# Altium schematic sheet Microbit built-in.SchDoc
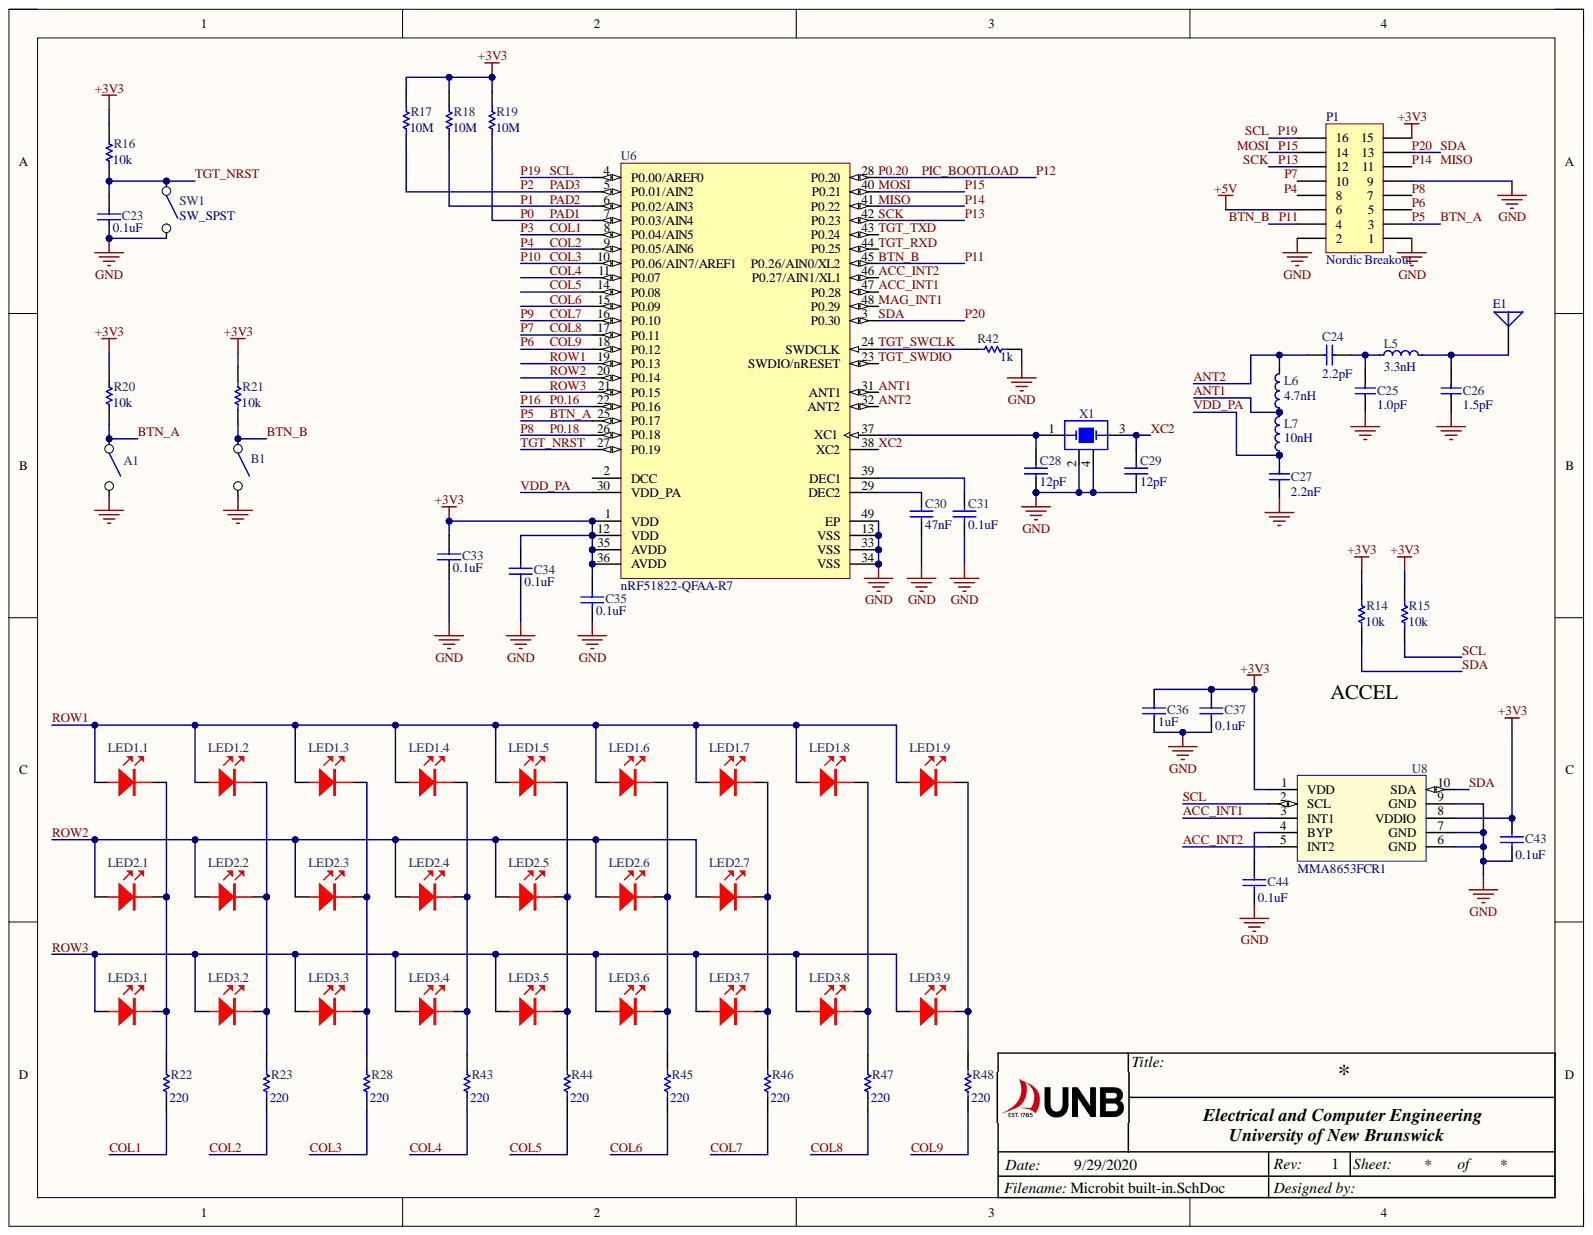
[diagram p0: Microbit built-in.SchDoc]

## Parts
A1  SW_SPST_6MM  [SW_FSM4JSMA]  (value not drawn)
B1  SW_SPST_6MM  [SW_FSM4JSMA]  (value not drawn)
C23  0.1uF  [0603 Cap]
C24  2.2pF  [CAPC1005X04L]
C25  1.0pF  [CAPC1005X04L]
C26  1.5pF  [CAPC1005X04L]
C27  2.2nF  [CAPC1005X04L]
C28  12pF  [CAPC1005X04L]
C29  12pF  [CAPC1005X04L]
C30  47nF  [CAPC1005X04L]
C31  0.1uF  [CAPC1005X04L]
C33  0.1uF  [CAPC1005X04L]
C34  0.1uF  [CAPC1005X04L]
C35  0.1uF  [CAPC1005X04L]
C36  1uF  [0603 Cap]
C37  0.1uF  [0603 Cap]
C43  0.1uF  [0603 Cap]
C44  0.1uF  [0603 Cap]
E1  ANT-2.45-CHP-T, 2.4GHz, 802.11, Bluetooth, SMD  [ANT-2.45-CHP]  (value not drawn)
L5  3.3nH  [INDC1005X04L]
L6  4.7nH  [INDC1005X04L]
L7  10nH  [INDC1005X04L]
LED1.1  LED_0603_RED  [LED_0603_RED]  (value not drawn)
LED1.2  LED_0603_RED  [LED_0603_RED]  (value not drawn)
LED1.3  LED_0603_RED  [LED_0603_RED]  (value not drawn)
LED1.4  LED_0603_RED  [LED_0603_RED]  (value not drawn)
LED1.5  LED_0603_RED  [LED_0603_RED]  (value not drawn)
LED1.6  LED_0603_RED  [LED_0603_RED]  (value not drawn)
LED1.7  LED_0603_RED  [LED_0603_RED]  (value not drawn)
LED1.8  LED_0603_RED  [LED_0603_RED]  (value not drawn)
LED1.9  LED_0603_RED  [LED_0603_RED]  (value not drawn)
LED2.1  LED_0603_RED  [LED_0603_RED]  (value not drawn)
LED2.2  LED_0603_RED  [LED_0603_RED]  (value not drawn)
LED2.3  LED_0603_RED  [LED_0603_RED]  (value not drawn)
LED2.4  LED_0603_RED  [LED_0603_RED]  (value not drawn)
LED2.5  LED_0603_RED  [LED_0603_RED]  (value not drawn)
LED2.6  LED_0603_RED  [LED_0603_RED]  (value not drawn)
LED2.7  LED_0603_RED  [LED_0603_RED]  (value not drawn)
LED3.1  LED_0603_RED  [LED_0603_RED]  (value not drawn)
LED3.2  LED_0603_RED  [LED_0603_RED]  (value not drawn)
LED3.3  LED_0603_RED  [LED_0603_RED]  (value not drawn)
LED3.4  LED_0603_RED  [LED_0603_RED]  (value not drawn)
LED3.5  LED_0603_RED  [LED_0603_RED]  (value not drawn)
LED3.6  LED_0603_RED  [LED_0603_RED]  (value not drawn)
LED3.7  LED_0603_RED  [LED_0603_RED]  (value not drawn)
LED3.8  LED_0603_RED  [LED_0603_RED]  (value not drawn)
LED3.9  LED_0603_RED  [LED_0603_RED]  (value not drawn)
P1  Nordic Breakout  [HDR2X8]
R14  10k  [0603 Resistor]
R15  10k  [0603 Resistor]
R16  10k  [0603 Resistor]
R17  10M  [0603 Resistor]
R18  10M  [0603 Resistor]
R19  10M  [0603 Resistor]
R20  10k  [0603 Resistor]
R21  10k  [0603 Resistor]
R22  220  [0603 Resistor]
R23  220  [0603 Resistor]
R28  220  [0603 Resistor]
R42  1k  [RESC1005X04L]
R43  220  [0603 Resistor]
R44  220  [0603 Resistor]
R45  220  [0603 Resistor]
R46  220  [0603 Resistor]
R47  220  [0603 Resistor]
R48  220  [0603 Resistor]
SW1  SW_SPST  [PTS636 SK25F SMTR LFS]
U6  nRF51822-QFAA-R7  [NRSC-QF-48_L]
U8  MMA8653FCR1  [IC_QFN40P200X200X85-10M]
X1  XTAL 16MHZ 2.5 x 2 mm  [BT-XTAL_2520]  (value not drawn)

## Nets
+3V3: C33.1 C34.1 C35.1 C36.2 C37.2 C43.2 P1.15 R14.1 R15.1 R16.1 R17.1 R18.1 R19.1 R20.1 R21.1 U6.1(VDD) U6.12(VDD) U6.35(AVDD) U6.36(AVDD) U8.1(VDD) U8.8(VDDIO)
+5V: P1.6
GND: A1.2 B1.2 C23.1 C25.2 C26.2 C27.2 C28.2 C29.2 C30.2 C31.2 C33.2 C34.2 C35.2 C36.1 C37.1 C43.1 C44.1 P1.1 P1.2 P1.9 R42.1 SW1.2 U6.13(VSS) U6.33(VSS) U6.34(VSS) U6.49(EP) U8.6(GND) U8.7(GND) U8.9(GND) X1.2 X1.4
ACC_INT1: U6.47(P0.28) U8.3(INT1)
ACC_INT2: U6.46(P0.27/AIN1/XL1) U8.5(INT2)
ANT1: L6.1 L7.2 U6.31(ANT1)
ANT2: C24.1 L6.2 U6.32(ANT2)
BTN_A: A1.1 P1.3 R20.2 U6.25(P0.17)
BTN_B: B1.1 P1.4 R21.2 U6.45(P0.26/AIN0/XL2)
COL1: R22.2 U6.8(P0.04/AIN5)
COL2: P1.8 R23.2 U6.9(P0.05/AIN6)
COL3: R28.2 U6.10(P0.06/AIN7/AREF1)
COL4: R43.2 U6.11(P0.07)
COL5: R44.2 U6.14(P0.08)
COL6: R45.2 U6.15(P0.09)
COL7: R46.2 U6.16(P0.10)
COL8: P1.10 R47.2 U6.17(P0.11)
COL9: P1.5 R48.2 U6.18(P0.12)
MAG_INT1: U6.48(P0.29)
MISO: P1.11 U6.41(P0.22)
MOSI: P1.14 U6.40(P0.21)
P0: R19.2 U6.7(P0.03/AIN4)
P0.16: U6.22(P0.16)
P0.18: P1.7 U6.26(P0.18)
P0.20: U6.28(P0.20)
P1: R18.2 U6.6(P0.02/AIN3)
P2: R17.2 U6.5(P0.01/AIN2)
P13: P1.12 U6.42(P0.23)
P19: P1.16 R15.2 U6.4(P0.00/AREF0) U8.2(SCL)
P20: P1.13 R14.2 U6.3(P0.30) U8.10(SDA)
ROW1: LED1.1.A LED1.2.A LED1.3.A LED1.4.A LED1.5.A LED1.6.A LED1.7.A LED1.8.A LED1.9.A U6.19(P0.13)
ROW2: LED2.1.A LED2.2.A LED2.3.A LED2.4.A LED2.5.A LED2.6.A LED2.7.A U6.20(P0.14)
ROW3: LED3.1.A LED3.2.A LED3.3.A LED3.4.A LED3.5.A LED3.6.A LED3.7.A LED3.8.A LED3.9.A U6.21(P0.15)
TGT_NRST: C23.2 R16.2 SW1.1 U6.27(P0.19)
TGT_RXD: U6.44(P0.25)
TGT_SWCLK: R42.2 U6.24(SWDCLK)
TGT_SWDIO: U6.23(SWDIO/nRESET)
TGT_TXD: U6.43(P0.24)
VDD_PA: C27.1 L7.1 U6.30(VDD_PA)
XC2: C29.1 U6.38(XC2) X1.3
NetC24_2: C24.2 C25.1 L5.1
NetC26_1: C26.1 E1.1 L5.2
NetC28_1: C28.1 U6.37(XC1) X1.1
NetC30_1: C30.1 U6.29(DEC2)
NetC31_1: C31.1 U6.39(DEC1)
NetC44_2: C44.2 U8.4(BYP)
NetLED1.1_K: LED1.1.K LED2.1.K LED3.1.K R22.1
NetLED1.2_K: LED1.2.K LED2.2.K LED3.2.K R23.1
NetLED1.3_K: LED1.3.K LED2.3.K LED3.3.K R28.1
NetLED1.4_K: LED1.4.K LED2.4.K LED3.4.K R43.1
NetLED1.5_K: LED1.5.K LED2.5.K LED3.5.K R44.1
NetLED1.6_K: LED1.6.K LED2.6.K LED3.6.K R45.1
NetLED1.7_K: LED1.7.K LED2.7.K LED3.7.K R46.1
NetLED1.8_K: LED1.8.K LED3.8.K R47.1
NetLED1.9_K: LED1.9.K LED3.9.K R48.1

Not connected: U6 2(DCC)

## Library data of the parts
A1 B1 = SW_SPST_6MM: Switch Tactile OFF (ON) SPST Round Button Gull Wing 0.05A 12VDC 1.57N SMD Loose Piece; Manufacturer: TE Connectivity; Manufacturer Part Number: FSM4JSMA; Actuator Length: 1.4mm; Contact Current Rating: 50mA; Contact Resistance: 100mR; Electrical Life: 100000Cycles; Max Voltage Rating (DC): 12V; Case/Package: SMD/SMT; Category: Pushbutton Switches; Color: Black; Component Kind: Standard; ComponentLink1Description: https://datasheet.ciiva.com/14635/0900766b80912216-14635826.pdf?src-supplier=Allied+Electronics; ComponentLink1URL: https://datasheet.ciiva.com/14635/0900766b80912216-14635826.pdf?src-supplier=Allied+Electronics; Contact Material: Copper Alloy,Silver; Contact Plating: Silver; Depth: 10mm; ELV: Compliant; Insulation Resistance: 100MR; Lead Free: Lead Free; Length: 6mm; Max Current Rating: 50mA; Max Operating Temperature: 85°C; Min Operating Temperature: -20°C; Mount: Surface Mount; Operating Force: 1.57N; Orientation: Straight; Packaging: Bulk; Radiation Hardening: No; REACH SVHC: No SVHC; RoHS: Yes; Stock 1: 18617; Supplier 1: Digi-Key; Supplier Part Number 1: 450-1129-ND; Termination: Gull Wing; Throw Configuration: SPST; Voltage Rating (DC): 12V; Library Name: Arbury_SCH.SchLib
C23 C37 C43 C44 = Cap Semi: Cap Ceramic 0.1uF 50VDC X7R 10% SMD 0603 Paper T/R; Manufacturer: Samsung; Manufacturer Part Number: CL10B104KB8NNNC; Capacitance: 100nF; Voltage: 50V; Case Code (Imperial): 0603; Case Code (Metric): 1608; Case/Package: 0603; Category: Ceramic Capacitors; Component Kind: Standard; ComponentLink1Description: http://www.avx.com/docs/Catalogs/cx7r.pdf; ComponentLink1URL: http://www.avx.com/docs/Catalogs/cx7r.pdf; ComponentLink2Description: https://datasheet.ciiva.com/25803/cl10b104kb8nnnc-25803393.pdf?src-supplier=Digi-Key; ComponentLink2URL: https://datasheet.ciiva.com/25803/cl10b104kb8nnnc-25803393.pdf?src-supplier=Digi-Key; ComponentLink3Description: https://datasheet.ciiva.com/26838/getdatasheetpartid-89097-26838411.pdf?src-supplier=Verical; ComponentLink3URL: https://datasheet.ciiva.com/26838/getdatasheetpartid-89097-26838411.pdf?src-supplier=Verical; ComponentLink4Description: https://datasheet.ciiva.com/29198/456651-da-01-en-vs-kondensator-0605-29198839.pdf?src-supplier=Conrad; ComponentLink4URL: https://datasheet.ciiva.com/29198/456651-da-01-en-vs-kondensator-0605-29198839.pdf?src-supplier=Conrad; Contact Plating: Tin; Depth: 0.8mm; Dielectric: X7R; Height: 0.8mm; Lead Free: Lead Free; Length: 1.6mm; Library Name: CAN_Interface_Library.SCHLIB; Material: Ceramic; Max Operating Temperature: 125°C; Min Operating Temperature: -55°C; Mount: Surface Mount; Package Quantity: 1; Packaging: Tape and Reel; Radiation Hardening: No; RoHS: Yes; Signal Integrity: Cap Semi; Simulation: CAP; Supplier 1: Digi-Key; Thickness: 0.035inch; Tolerance: 10%; Value: 0.1uF; Voltage Rating: 50V; Voltage Rating (DC): 50V; Width: 0.031inch
  C23: Stock 1: 17879275; Supplier Part Number 1: 1276-1000-6-ND
  C37 C43 C44: Stock 1: 17674061; Supplier Part Number 1: 1276-1000-1-ND
C24 = C0402-2.2p: Murata 2.2pF Multilayer Ceramic Capacitor (MLCC) 50 V dc 0.25pF C0G dielectric GJM max op. temp. +125C; Manufacturer Part Number: GJM1555C1H2R2CB01D; Database Table Name: Capacitors$; Voltage: 50V; Capacitance: 2.2pF; Case Code (Imperial): 0402; Case Code (Metric): 1005; Case/Package: 0402; Category: Ceramic Capacitors; Component Kind: Standard; ComponentLink1Description: https://datasheet.ciiva.com/17453/product-17453491.pdf?src-supplier=Avnet+Europe; ComponentLink1URL: https://datasheet.ciiva.com/17453/product-17453491.pdf?src-supplier=Avnet+Europe; ComponentLink2Description: https://datasheet.ciiva.com/18183/getdatasheetpartid-370505-18183831.pdf?src-supplier=Verical; ComponentLink2URL: https://datasheet.ciiva.com/18183/getdatasheetpartid-370505-18183831.pdf?src-supplier=Verical; ComponentLink3Description: https://datasheet.ciiva.com/132/partnumbering-e-01-132409.pdf?src-supplier=Digi-Key; ComponentLink3URL: https://datasheet.ciiva.com/132/partnumbering-e-01-132409.pdf?src-supplier=Digi-Key; ComponentLink4Description: https://datasheet.ciiva.com/132/partnumbering-e-01-132410.pdf?src-supplier=Digi-Key; ComponentLink4URL: https://datasheet.ciiva.com/132/partnumbering-e-01-132410.pdf?src-supplier=Digi-Key; ComponentLink5Description: https://datasheet.ciiva.com/132/partnumbering-e-01-132411.pdf?src-supplier=Digi-Key; ComponentLink5URL: https://datasheet.ciiva.com/132/partnumbering-e-01-132411.pdf?src-supplier=Digi-Key; ComponentLink6Description: https://datasheet.ciiva.com/16440/l0005e-16440478.pdf?src-supplier=Future+Electronics; ComponentLink6URL: https://datasheet.ciiva.com/16440/l0005e-16440478.pdf?src-supplier=Future+Electronics; Depth: 0.5mm; Dielectric: C0G; Height: 0.5mm; Lead Free: Lead Free; Length: 1mm; Library Name: Nordic Passive DB.DbLib; Manufacturer: Murata; Max Operating Temperature: 125°C; Min Operating Temperature: -55°C; Mount: Surface Mount; Package Quantity: 1; Packaging: Tape and Reel; Radiation Hardening: No; RoHS: Yes; Stock 1: 101982; Supplier 1: Digi-Key; Supplier Part Number 1: 490-3091-1-ND; Termination: SMD/SMT; Thickness: 0.022inch; Value: 2.2pF; Voltage Rating: 50V; Voltage Rating (DC): 50V; Width: 0.02inch
C25 = C0402-1.0p: CAP CER 1PF 50V C0G/NP0 0402; Manufacturer: Murata; Manufacturer Part Number: GJM1555C1H1R0CB01D; Database Table Name: Capacitors$; Dielectric: C0G; Temperature Coefficient: 30ppm/°C; Capacitance: 1pF; Case Code (Imperial): 0402; Case Code (Metric): 1005; Case/Package: 0402; Category: Ceramic Capacitors; Component Kind: Standard; ComponentLink1Description: https://datasheet.ciiva.com/133/product-133104.pdf?src-supplier=Digi-Key; ComponentLink1URL: https://datasheet.ciiva.com/133/product-133104.pdf?src-supplier=Digi-Key; ComponentLink2Description: https://datasheet.ciiva.com/8030/1700189-8030101.pdf?src-supplier=Element14; ComponentLink2URL: https://datasheet.ciiva.com/8030/1700189-8030101.pdf?src-supplier=Element14; ComponentLink3Description: https://datasheet.ciiva.com/18183/getdatasheetpartid-254995-18183819.pdf?src-supplier=Verical; ComponentLink3URL: https://datasheet.ciiva.com/18183/getdatasheetpartid-254995-18183819.pdf?src-supplier=Verical; ComponentLink4Description: https://datasheet.ciiva.com/11789/0900766b80ef921d-11789100.pdf?src-supplier=RSComponents; ComponentLink4URL: https://datasheet.ciiva.com/11789/0900766b80ef921d-11789100.pdf?src-supplier=RSComponents; ComponentLink5Description: https://datasheet.ciiva.com/133/partnumbering-e-01-133102.pdf?src-supplier=Digi-Key; ComponentLink5URL: https://datasheet.ciiva.com/133/partnumbering-e-01-133102.pdf?src-supplier=Digi-Key; ComponentLink6Description: https://datasheet.ciiva.com/133/partnumbering-e-01-133103.pdf?src-supplier=Digi-Key; ComponentLink6URL: https://datasheet.ciiva.com/133/partnumbering-e-01-133103.pdf?src-supplier=Digi-Key; Depth: 0.5mm; Height: 0.5mm; Lead Free: Lead Free; Lead Pitch: 0.3mm; Length: 1mm; Library Name: Nordic Passive DB.DbLib; Max Operating Temperature: 125°C; Min Operating Temperature: -55°C; Mount: Surface Mount; Number of Pins: 2; Package Quantity: 1; Packaging: Tape and Reel; Radiation Hardening: No; REACH SVHC: No SVHC; RoHS: Yes; Stock 1: 37797; Supplier 1: Digi-Key; Supplier Part Number 1: 490-3083-1-ND; Termination: SMD/SMT; Thickness: 0.022inch; Value: 1.0pF; Voltage: 50V; Voltage Rating: 50V; Voltage Rating (DC): 50V; Width: 0.5mm
C26 = C0402-1.5p: CAP CER 1.5PF 50V C0G/NP0 0402; Manufacturer: Murata; Manufacturer Part Number: GJM1555C1H1R5CB01D; Database Table Name: Capacitors$; Dielectric: C0G; Capacitance: 1.5pF; Case Code (Imperial): 0402; Case Code (Metric): 1005; Case/Package: 0402; Category: Ceramic Capacitors; Component Kind: Standard; ComponentLink1Description: https://datasheet.ciiva.com/133/product-133136.pdf?src-supplier=Digi-Key; ComponentLink1URL: https://datasheet.ciiva.com/133/product-133136.pdf?src-supplier=Digi-Key; ComponentLink2Description: https://datasheet.ciiva.com/18183/getdatasheetpartid-306679-18183822.pdf?src-supplier=Verical; ComponentLink2URL: https://datasheet.ciiva.com/18183/getdatasheetpartid-306679-18183822.pdf?src-supplier=Verical; ComponentLink3Description: https://datasheet.ciiva.com/133/partnumbering-e-01-133137.pdf?src-supplier=Digi-Key; ComponentLink3URL: https://datasheet.ciiva.com/133/partnumbering-e-01-133137.pdf?src-supplier=Digi-Key; ComponentLink4Description: https://datasheet.ciiva.com/17453/product-17453482.pdf?src-supplier=Avnet+Europe; ComponentLink4URL: https://datasheet.ciiva.com/17453/product-17453482.pdf?src-supplier=Avnet+Europe; ComponentLink5Description: https://datasheet.ciiva.com/133/partnumbering-e-01-133135.pdf?src-supplier=Digi-Key; ComponentLink5URL: https://datasheet.ciiva.com/133/partnumbering-e-01-133135.pdf?src-supplier=Digi-Key; Depth: 0.5mm; Height: 0.5mm; Lead Free: Lead Free; Length: 1mm; Library Name: Nordic Passive DB.DbLib; Max Operating Temperature: 125°C; Min Operating Temperature: -55°C; Mount: Surface Mount; Package Quantity: 1; Packaging: Tape and Reel; Radiation Hardening: No; RoHS: Yes; Stock 1: 113468; Supplier 1: Digi-Key; Supplier Part Number 1: 490-3087-1-ND; Termination: SMD/SMT; Thickness: 0.022inch; Value: 1.5pF; Voltage: 50V; Voltage Rating: 50V; Voltage Rating (DC): 50V; Width: 0.02inch
C27 = C0402-2n2: MURATA - GRM155R71H222KA01D - Keramikvielschichtkondensator, SMD, 2200 pF, 50 V, 0402 [Metrisch 1005], ± 10%, X7R, GRM Series; Database Table Name: Capacitors$; Material: Ceramic; Voltage: 50V; Capacitance: 2.2nF; Case Code (Imperial): 0402; Case Code (Metric): 1005; Case/Package: 0402; Category: Ceramic Capacitors; Component Kind: Standard; ComponentLink1Description: https://datasheet.ciiva.com/11786/0900766b80ef921d-11786696.pdf?src-supplier=RSComponents; ComponentLink1URL: https://datasheet.ciiva.com/11786/0900766b80ef921d-11786696.pdf?src-supplier=RSComponents; ComponentLink2Description: https://datasheet.ciiva.com/18184/getdatasheetpartid-127769-18184735.pdf?src-supplier=Verical; ComponentLink2URL: https://datasheet.ciiva.com/18184/getdatasheetpartid-127769-18184735.pdf?src-supplier=Verical; ComponentLink3Description: https://datasheet.ciiva.com/34302/1856468-34302855.pdf?src-supplier=Jameco; ComponentLink3URL: https://datasheet.ciiva.com/34302/1856468-34302855.pdf?src-supplier=Jameco; ComponentLink4Description: https://datasheet.ciiva.com/29199/454279-da-01-en-vs-kondensator-0402-29199761.pdf?src-supplier=Conrad; ComponentLink4URL: https://datasheet.ciiva.com/29199/454279-da-01-en-vs-kondensator-0402-29199761.pdf?src-supplier=Conrad; ComponentLink5Description: https://datasheet.ciiva.com/22698/608985-22698423.pdf?src-supplier=Element14; ComponentLink5URL: https://datasheet.ciiva.com/22698/608985-22698423.pdf?src-supplier=Element14; ComponentLink6Description: https://datasheet.ciiva.com/129/partnumbering-e-01-129864.pdf?src-supplier=Digi-Key; ComponentLink6URL: https://datasheet.ciiva.com/129/partnumbering-e-01-129864.pdf?src-supplier=Digi-Key; ComponentLink7Description: https://datasheet.ciiva.com/129/partnumbering-e-01-129866.pdf?src-supplier=Digi-Key; ComponentLink7URL: https://datasheet.ciiva.com/129/partnumbering-e-01-129866.pdf?src-supplier=Digi-Key; ComponentLink8Description: https://datasheet.ciiva.com/14116/grmseries-highdielectrictype-eng-14116908.pdf?src-supplier=Distrelec; ComponentLink8URL: https://datasheet.ciiva.com/14116/grmseries-highdielectrictype-eng-14116908.pdf?src-supplier=Distrelec; ComponentLink9Description: https://datasheet.ciiva.com/129/partnumbering-e-01-129865.pdf?src-supplier=Digi-Key; ComponentLink9URL: https://datasheet.ciiva.com/129/partnumbering-e-01-129865.pdf?src-supplier=Digi-Key; ComponentLink10Description: https://datasheet.ciiva.com/7937/608985-7937628.pdf?src-supplier=Element14; ComponentLink10URL: https://datasheet.ciiva.com/7937/608985-7937628.pdf?src-supplier=Element14; ComponentLink11Description: https://datasheet.ciiva.com/14116/grmseries-highdielectrictype-eng-14116847.pdf?src-supplier=Distrelec; ComponentLink11URL: https://datasheet.ciiva.com/14116/grmseries-highdielectrictype-eng-14116847.pdf?src-supplier=Distrelec; Depth: 0.5mm; Dielectric: X7R; Height: 0.5mm; Lead Free: Lead Free; Length: 1mm; Library Name: Nordic Passive DB.DbLib; Manufacturer: Murata; Manufacturer Part Number: GRM155R71H222KA01D; Max Operating Temperature: 125°C; Min Operating Temperature: -55°C; Mount: Surface Mount; Number of Pins: 2; Package Quantity: 1; Packaging: Tape and Reel; Radiation Hardening: No; REACH SVHC: No SVHC; RoHS: Yes; Stock 1: 1354506; Supplier 1: Digi-Key; Supplier Part Number 1: 490-1305-1-ND; Termination: SMD/SMT; Thickness: 0.022inch; Tolerance: 10%; Value: 2.2nF; Voltage Rating: 50V; Voltage Rating (DC): 50V; Weight: 2.3E-05oz; Width: 0.5mm
C28 C29 = Cap Semi: CAP CER 12PF 50V C0G/NPO 0402; Manufacturer: Yageo; Manufacturer Part Number: CC0402JRNPO9BN120; Dielectric: C0G; Tolerance: 5%; Capacitance: 12pF; Case Code (Imperial): 0402; Case Code (Metric): 1005; Case/Package: 0402; Category: Ceramic Capacitors; Component Kind: Standard; ComponentLink1Description: http://www.avx.com/docs/Catalogs/cx7r.pdf; ComponentLink1URL: http://www.avx.com/docs/Catalogs/cx7r.pdf; ComponentLink2Description: https://datasheet.ciiva.com/161/mlccorderingcode-161488.pdf?src-supplier=Digi-Key; ComponentLink2URL: https://datasheet.ciiva.com/161/mlccorderingcode-161488.pdf?src-supplier=Digi-Key; ComponentLink3Description: https://datasheet.ciiva.com/5425/upy-gp-np0-16v-to-50v-8-5425431.pdf?src-supplier=Mouser; ComponentLink3URL: https://datasheet.ciiva.com/5425/upy-gp-np0-16v-to-50v-8-5425431.pdf?src-supplier=Mouser; ComponentLink4Description: https://datasheet.ciiva.com/11587/upy-gp-np0-16v-to-50v-8-11587083.pdf?src-supplier=Avnet; ComponentLink4URL: https://datasheet.ciiva.com/11587/upy-gp-np0-16v-to-50v-8-11587083.pdf?src-supplier=Avnet; ComponentLink5Description: https://datasheet.ciiva.com/17434/upy-gp-np0-16v-to-50v-8-17434331.pdf?src-supplier=Avnet+Europe; ComponentLink5URL: https://datasheet.ciiva.com/17434/upy-gp-np0-16v-to-50v-8-17434331.pdf?src-supplier=Avnet+Europe; ComponentLink6Description: https://datasheet.ciiva.com/161/mlccorderingcode-161486.pdf?src-supplier=Digi-Key; ComponentLink6URL: https://datasheet.ciiva.com/161/mlccorderingcode-161486.pdf?src-supplier=Digi-Key; ComponentLink7Description: https://datasheet.ciiva.com/28450/1443161-28450222.pdf?src-supplier=Element14; ComponentLink7URL: https://datasheet.ciiva.com/28450/1443161-28450222.pdf?src-supplier=Element14; ComponentLink8Description: https://datasheet.ciiva.com/161/mlccorderingcode-161487.pdf?src-supplier=Digi-Key; ComponentLink8URL: https://datasheet.ciiva.com/161/mlccorderingcode-161487.pdf?src-supplier=Digi-Key; Composition: Ceramic; Depth: 0.5mm; Height: 0.5mm; Lead Free: Lead Free; Length: 1mm; Library Name: CAN_Interface_Library.SCHLIB; Max Operating Temperature: 125°C; Min Operating Temperature: -55°C; Mount: Surface Mount; Number of Pins: 2; Package Quantity: 1; Packaging: Tape and Reel; Radiation Hardening: No; REACH SVHC: No SVHC; RoHS: Yes; Signal Integrity: Cap Semi; Simulation: CAP; Stock 1: 989693; Supplier 1: Digi-Key; Supplier Part Number 1: 311-1016-1-ND; Termination: SMD/SMT; Thickness: 0.022inch; Value: 12pF; Voltage Rating: 50V; Voltage Rating (DC): 50V; Width: 0.02inch
C30 = Cap Semi: CAP CER 0.047UF 10V X7R 0402; Manufacturer: Samsung; Manufacturer Part Number: CL05B473KP5NNNC; Tolerance: 10%; Capacitance: 47nF; Case Code (Imperial): 0402; Case Code (Metric): 1005; Case/Package: 0402; Category: Ceramic Capacitors; Component Kind: Standard; ComponentLink1Description: http://www.avx.com/docs/Catalogs/cx7r.pdf; ComponentLink1URL: http://www.avx.com/docs/Catalogs/cx7r.pdf; ComponentLink2Description: https://datasheet.ciiva.com/16428/cl05b473kp5nnnc-16428818.pdf?src-supplier=Future+Electronics; ComponentLink2URL: https://datasheet.ciiva.com/16428/cl05b473kp5nnnc-16428818.pdf?src-supplier=Future+Electronics; ComponentLink3Description: https://datasheet.ciiva.com/25806/cl05b473kp5nnnc-25806114.pdf?src-supplier=Digi-Key; ComponentLink3URL: https://datasheet.ciiva.com/25806/cl05b473kp5nnnc-25806114.pdf?src-supplier=Digi-Key; Depth: 0.5mm; Dielectric: X7R; Height: 0.5mm; Lead Free: Lead Free; Length: 1mm; Library Name: CAN_Interface_Library.SCHLIB; Max Operating Temperature: 125°C; Min Operating Temperature: -55°C; Mount: Surface Mount; Package Quantity: 1; Packaging: Tape and Reel; Radiation Hardening: No; RoHS: Yes; Signal Integrity: Cap Semi; Simulation: CAP; Stock 1: 241857; Supplier 1: Digi-Key; Supplier Part Number 1: 1276-1573-1-ND; Thickness: 0.022inch; Value: 47nF; Voltage Rating: 10V; Voltage Rating (DC): 10V; Width: 0.02inch
C31 C33-C35 = Cap Semi: Prices include import duty and tax. CAP, 0.1UF, 25V, MLCC, 0402; SVHC: No SVHC (15-Jan-2019); Manufacturer: Samsung; Manufacturer Part Number: CL05A104KA5NNNC; Capacitance: 100nF; Dielectric: X5R; Tolerance: 10%; Case Code (Imperial): 0402; Case Code (Metric): 1005; Case/Package: 0402; Category: Ceramic Capacitors; Component Kind: Standard; ComponentLink1Description: http://www.avx.com/docs/Catalogs/cx7r.pdf; ComponentLink1URL: http://www.avx.com/docs/Catalogs/cx7r.pdf; ComponentLink2Description: https://datasheet.ciiva.com/25802/cl05a104ka5nnnc-25802704.pdf?src-supplier=Digi-Key; ComponentLink2URL: https://datasheet.ciiva.com/25802/cl05a104ka5nnnc-25802704.pdf?src-supplier=Digi-Key; ComponentLink3Description: https://datasheet.ciiva.com/16437/cl05a104ka5nnnc-16437369.pdf?src-supplier=Future+Electronics; ComponentLink3URL: https://datasheet.ciiva.com/16437/cl05a104ka5nnnc-16437369.pdf?src-supplier=Future+Electronics; ComponentLink4Description: https://datasheet.ciiva.com/26838/getdatasheetpartid-357412-26838142.pdf?src-supplier=Verical; ComponentLink4URL: https://datasheet.ciiva.com/26838/getdatasheetpartid-357412-26838142.pdf?src-supplier=Verical; ComponentLink5Description: https://datasheet.ciiva.com/26309/mlcc-catalogdownload2013-eng-26309014.pdf?src-supplier=Distrelec; ComponentLink5URL: https://datasheet.ciiva.com/26309/mlcc-catalogdownload2013-eng-26309014.pdf?src-supplier=Distrelec; Depth: 0.5mm; Height: 0.5mm; Lead Free: Lead Free; Length: 1mm; Library Name: CAN_Interface_Library.SCHLIB; Max Operating Temperature: 85°C; Min Operating Temperature: -55°C; Mount: Surface Mount; Package Quantity: 1; Packaging: Tape and Reel; Radiation Hardening: No; RoHS: Yes; Signal Integrity: Cap Semi; Simulation: CAP; Stock 1: 1425824; Supplier 1: Digi-Key; Supplier Part Number 1: 1276-1043-1-ND; Thickness: 0.022inch; Value: 0.1uF; Voltage: 25V; Voltage Rating: 25V; Voltage Rating (DC): 25V; Width: 0.02inch
C36 = Cap Semi: CAP CER 1UF 10V X7R 0603; Manufacturer: Samsung; Manufacturer Part Number: CL10B105KP8NFNC; Tolerance: 10%; Capacitance: 1uF; Case Code (Imperial): 0603; Case Code (Metric): 1608; Case/Package: 0603; Category: Ceramic Capacitors; Component Kind: Standard; ComponentLink1Description: http://www.avx.com/docs/Catalogs/cx7r.pdf; ComponentLink1URL: http://www.avx.com/docs/Catalogs/cx7r.pdf; ComponentLink2Description: https://datasheet.ciiva.com/25737/cl10b105kp8nfnc-25737841.pdf?src-supplier=Digi-Key; ComponentLink2URL: https://datasheet.ciiva.com/25737/cl10b105kp8nfnc-25737841.pdf?src-supplier=Digi-Key; Depth: 0.8mm; Dielectric: X7R; Height: 0.8mm; Length: 0.063inch; Library Name: CAN_Interface_Library.SCHLIB; Max Operating Temperature: 125°C; Min Operating Temperature: -55°C; Mount: Surface Mount; Package Quantity: 1; Packaging: Tape and Reel; Radiation Hardening: No; RoHS: Yes; Signal Integrity: Cap Semi; Simulation: CAP; Stock 1: 148444; Supplier 1: Digi-Key; Supplier Part Number 1: 1276-1945-1-ND; Thickness: 0.035inch; Value: 1uF; Voltage Rating: 10V; Width: 0.031inch
E1 = Antenna: RF ANT 2.4GHZ CHIP SOLDER SMD; Manufacturer: Linx; Bandwidth: 180MHz; Frequency: 2.45GHz; Gain: 0.5dB; Impedance: 50R; Max Power Dissipation: 3W; Number of Bands: 1; Case/Package: SMD/SMT; Category: RF Antennas; Component Kind: Standard; ComponentLink1Description: https://datasheet.ciiva.com/16287/ant-xxx-chp-x-16287420.pdf?src-supplier=Future+Electronics; ComponentLink1URL: https://datasheet.ciiva.com/16287/ant-xxx-chp-x-16287420.pdf?src-supplier=Future+Electronics; ComponentLink2Description: https://datasheet.ciiva.com/2792/ant-xxx-chp-x-2792221.pdf?src-supplier=Digi-Key; ComponentLink2URL: https://datasheet.ciiva.com/2792/ant-xxx-chp-x-2792221.pdf?src-supplier=Digi-Key; ComponentLink3Description: https://datasheet.ciiva.com/2792/ant-xxx-chp-x-2792222.pdf?src-supplier=Digi-Key; ComponentLink3URL: https://datasheet.ciiva.com/2792/ant-xxx-chp-x-2792222.pdf?src-supplier=Digi-Key; ComponentLink4Description: https://datasheet.ciiva.com/2792/ant-xxx-chp-x-2792223.pdf?src-supplier=Digi-Key; ComponentLink4URL: https://datasheet.ciiva.com/2792/ant-xxx-chp-x-2792223.pdf?src-supplier=Digi-Key; ComponentLink5Description: https://datasheet.ciiva.com/4432/ant-xxx-chp-x-data-guide-11938-4432415.pdf?src-supplier=Mouser; ComponentLink5URL: https://datasheet.ciiva.com/4432/ant-xxx-chp-x-data-guide-11938-4432415.pdf?src-supplier=Mouser; Height: 0.002inch; Lead Free: Lead Free; Length: 0.26inch; Library Name: Miscellaneous Devices.IntLib; Manufacturer Part Number: ANT-2.45-CHP-T; Max Operating Temperature: 85°C; Min Operating Temperature: -40°C; Mount: Surface Mount; Package Quantity: 1; Packaging: Tape and Reel; RoHS: Yes; Stock 1: 10182; Supplier 1: Digi-Key; Supplier Part Number 1: ANT-2.45-CHPCT-ND; Termination: Solder; Width: 0.09inch
L5 = L0402-3n3: FIXED IND 3.3NH 190MA 400 MOHM; Manufacturer: Murata; Manufacturer Part Number: LQP15MN3N3B02D; Core Material: Non-Magnetic; Database Table Name: Inductors$; DC Resistance (DCR): 400mR; Q Factor: 13; Self Resonant Frequency: 6GHz; Shielding: Unshielded; Test Frequency: 500MHz; Case Code (Imperial): 0402; Case Code (Metric): 1005; Case/Package: 0402; Category: Fixed Inductors; Component Kind: Standard; ComponentLink1Description: https://datasheet.ciiva.com/11801/0900766b80f155d9-11801425.pdf?src-supplier=RSComponents; ComponentLink1URL: https://datasheet.ciiva.com/11801/0900766b80f155d9-11801425.pdf?src-supplier=RSComponents; ComponentLink2Description: https://datasheet.ciiva.com/16207/product-16207891.pdf?src-supplier=Future+Electronics; ComponentLink2URL: https://datasheet.ciiva.com/16207/product-16207891.pdf?src-supplier=Future+Electronics; ComponentLink3Description: https://datasheet.ciiva.com/27054/1865129-27054328.pdf?src-supplier=Jameco; ComponentLink3URL: https://datasheet.ciiva.com/27054/1865129-27054328.pdf?src-supplier=Jameco; ComponentLink4Description: https://datasheet.ciiva.com/1039/l0075s0084lqp15m-1039858.pdf?src-supplier=Digi-Key; ComponentLink4URL: https://datasheet.ciiva.com/1039/l0075s0084lqp15m-1039858.pdf?src-supplier=Digi-Key; ComponentLink5Description: https://datasheet.ciiva.com/1039/l0075s0084lqp15m-1039859.pdf?src-supplier=Digi-Key; ComponentLink5URL: https://datasheet.ciiva.com/1039/l0075s0084lqp15m-1039859.pdf?src-supplier=Digi-Key; ComponentLink6Description: https://datasheet.ciiva.com/1039/l0075s0084lqp15m-1039860.pdf?src-supplier=Digi-Key; ComponentLink6URL: https://datasheet.ciiva.com/1039/l0075s0084lqp15m-1039860.pdf?src-supplier=Digi-Key; ComponentLink7Description: https://datasheet.ciiva.com/17277/l0075s0084lqp15m-17277962.pdf?src-supplier=Avnet+Europe; ComponentLink7URL: https://datasheet.ciiva.com/17277/l0075s0084lqp15m-17277962.pdf?src-supplier=Avnet+Europe; Composition: Thin Film; Current Rating: 190mA; DC Current: 190mA; Depth: 0.6mm; Height: 0.35mm; Height - Seated (Max): 0.018inch; Inductance: 3.3nH; Lead Free: Lead Free; Length: 1.1mm; Library Name: Nordic Passive DB.DbLib; Max DC Current: 190mA; Max Operating Temperature: 85°C; Military Standard: Not; Min Operating Temperature: -40°C; Mount: Surface Mount; Package Quantity: 10000; Packaging: Tape and Reel; Radiation Hardening: No; RoHS: Yes; Stock 1: 18761; Supplier 1: Digi-Key; Supplier Part Number 1: 490-1130-1-ND; Termination: SMD/SMT; Value: 3.3nH; Width: 0.02inch
L6 = L0402-4n7: FIXED IND 4.7NH 160MA 600 MOHM; Manufacturer: Murata; Manufacturer Part Number: LQP15MN4N7B02D; Core Material: Non-Magnetic,Ferrite; DC Resistance (DCR): 600mR; Q Factor: 13; Self Resonant Frequency: 6GHz; Shielding: Unshielded; Test Frequency: 500MHz; Case Code (Imperial): 0402; Case Code (Metric): 1005; Case/Package: 0402; Category: Fixed Inductors; Component Kind: Standard; ComponentLink1Description: https://datasheet.ciiva.com/30490/0900766b81256025-30490310.pdf?src-supplier=RSComponents; ComponentLink1URL: https://datasheet.ciiva.com/30490/0900766b81256025-30490310.pdf?src-supplier=RSComponents; ComponentLink2Description: https://datasheet.ciiva.com/8507/l0075s0084lqp15m-8507286.pdf?src-supplier=Avnet; ComponentLink2URL: https://datasheet.ciiva.com/8507/l0075s0084lqp15m-8507286.pdf?src-supplier=Avnet; ComponentLink3Description: https://datasheet.ciiva.com/17277/l0075s0084lqp15m-17277974.pdf?src-supplier=Avnet+Europe; ComponentLink3URL: https://datasheet.ciiva.com/17277/l0075s0084lqp15m-17277974.pdf?src-supplier=Avnet+Europe; ComponentLink4Description: https://datasheet.ciiva.com/1039/l0075s0084lqp15m-1039864.pdf?src-supplier=Digi-Key; ComponentLink4URL: https://datasheet.ciiva.com/1039/l0075s0084lqp15m-1039864.pdf?src-supplier=Digi-Key; ComponentLink5Description: https://datasheet.ciiva.com/1039/l0075s0084lqp15m-1039865.pdf?src-supplier=Digi-Key; ComponentLink5URL: https://datasheet.ciiva.com/1039/l0075s0084lqp15m-1039865.pdf?src-supplier=Digi-Key; ComponentLink6Description: https://datasheet.ciiva.com/1039/l0075s0084lqp15m-1039866.pdf?src-supplier=Digi-Key; ComponentLink6URL: https://datasheet.ciiva.com/1039/l0075s0084lqp15m-1039866.pdf?src-supplier=Digi-Key; ComponentLink7Description: https://datasheet.ciiva.com/18230/getdatasheetpartid-97836-18230683.pdf?src-supplier=Verical; ComponentLink7URL: https://datasheet.ciiva.com/18230/getdatasheetpartid-97836-18230683.pdf?src-supplier=Verical; Composition: Thin Film; Current Rating: 160mA; DC Current: 160mA; Depth: 0.6mm; Height: 0.35mm; Height - Seated (Max): 0.018inch; Inductance: 4.7nH; Lead Free: Lead Free; Length: 1mm; Max DC Current: 160mA; Max Operating Temperature: 85°C; Military Standard: Not; Min Operating Temperature: -40°C; Mount: Surface Mount; Package Quantity: 1; Packaging: Tape and Reel; Radiation Hardening: No; RoHS: Yes; Stock 1: 5833; Supplier 1: Digi-Key; Supplier Part Number 1: 490-1132-1-ND; Termination: SMD/SMT; Value: 4.7nH; Width: 0.02inch; Library Name: nrf51x22_qfax.SchLib
L7 = L0402-10n: Murata LQP15M Series 10 nH 2% Ferrite Multilayer SMD Inductor, 0402 Case, SRF: 4.5GHz Q: 13 100mA dc 1.3 Rdc; Manufacturer Part Number: LQP15MN10NG02D; Database Table Name: Inductors$; DC Resistance (DCR): 1.3R; Q Factor: 13; Shielding: Unshielded; Test Frequency: 500MHz; Case Code (Imperial): 0402; Case Code (Metric): 1005; Case/Package: 0402; Category: Fixed Inductors; Component Kind: Standard; ComponentLink1Description: https://datasheet.ciiva.com/31395/5114-31395729.pdf?src-supplier=Farnell; ComponentLink1URL: https://datasheet.ciiva.com/31395/5114-31395729.pdf?src-supplier=Farnell; ComponentLink2Description: https://datasheet.ciiva.com/30490/0900766b81256025-30490303.pdf?src-supplier=RSComponents; ComponentLink2URL: https://datasheet.ciiva.com/30490/0900766b81256025-30490303.pdf?src-supplier=RSComponents; ComponentLink3Description: https://datasheet.ciiva.com/46414/5114-46414506.pdf?src-supplier=Element14; ComponentLink3URL: https://datasheet.ciiva.com/46414/5114-46414506.pdf?src-supplier=Element14; ComponentLink4Description: https://datasheet.ciiva.com/46414/5114-46414507.pdf?src-supplier=Element14; ComponentLink4URL: https://datasheet.ciiva.com/46414/5114-46414507.pdf?src-supplier=Element14; ComponentLink5Description: https://datasheet.ciiva.com/8510/l0075s0084lqp15m-8510524.pdf?src-supplier=Avnet; ComponentLink5URL: https://datasheet.ciiva.com/8510/l0075s0084lqp15m-8510524.pdf?src-supplier=Avnet; ComponentLink6Description: https://datasheet.ciiva.com/17277/l0075s0084lqp15m-17277918.pdf?src-supplier=Avnet+Europe; ComponentLink6URL: https://datasheet.ciiva.com/17277/l0075s0084lqp15m-17277918.pdf?src-supplier=Avnet+Europe; Core Material: Ferrite; Current Rating: 100mA; DC Current: 100mA; Depth: 0.6mm; Height: 0.35mm; Inductance: 10nH; Inductance Tolerance: 2%; Lead Free: Lead Free; Length: 1mm; Library Name: Nordic Passive DB.DbLib; Manufacturer: Murata; Max DC Current: 100mA; Max Operating Temperature: 85°C; Military Standard: Not; Min Operating Temperature: -40°C; Mount: Surface Mount; Package Quantity: 10000; Packaging: Tape and Reel; Radiation Hardening: No; REACH SVHC: No SVHC; RoHS: Yes; Self Resonant Frequency: 4.5GHz; Stock 1: 22987; Supplier 1: Digi-Key; Supplier Part Number 1: 490-6743-1-ND; Termination: SMD/SMT; Tolerance: 2%; Value: 10nH; Weight: 2.3E-05oz; Width: 0.02inch
LED1.1-LED1.9 LED2.1-LED2.7 LED3.1-LED3.9 = LED_0603_RED: LED, Red, 630 nm, 1.9 V, 30 mA, 60 mcd; Manufacturer: Wurth Electronics; Manufacturer Part Number: 150060SS75000; Dominant Wavelength: 630nm; Forward Current: 30mA; Forward Voltage: 1.9V; Lens Style: Clear,Rectangular; Luminous Intensity: 60mcd; Test Current: 20mA; Viewing Angle: 140°; Case Code (Imperial): 0603; Case Code (Metric): 1608; Case/Package: 0603; Category: LEDs; Color: Red; Component Kind: Standard; ComponentLink1Description: https://datasheet.ciiva.com/23843/150060ss75000-23843248.pdf?src-supplier=Newark; ComponentLink1URL: https://datasheet.ciiva.com/23843/150060ss75000-23843248.pdf?src-supplier=Newark; ComponentLink2Description: https://datasheet.ciiva.com/29279/759429-da-01-en-chipled-0603-w-kl-s-rot-150060ss75000-29279208.pdf?src-supplier=Conrad; ComponentLink2URL: https://datasheet.ciiva.com/29279/759429-da-01-en-chipled-0603-w-kl-s-rot-150060ss75000-29279208.pdf?src-supplier=Conrad; ComponentLink3Description: https://datasheet.ciiva.com/48430/150060ss75000-eng-tds-48430042.pdf?src-supplier=Distrelec; ComponentLink3URL: https://datasheet.ciiva.com/48430/150060ss75000-eng-tds-48430042.pdf?src-supplier=Distrelec; Height: 0.7mm; Length: 1.6mm; Max Operating Temperature: 85°C; Min Operating Temperature: -40°C; Mount: Surface Mount; Package Quantity: 4000; Packaging: Tape and Reel; REACH SVHC: Unknown; RoHS: Yes; Stock 1: 15937; Supplier 1: Digi-Key; Supplier Part Number 1: 732-4979-1-ND; Wavelength: 630nm; Width: 0.8mm; Library Name: Arbury_SCH.SchLib
P1 = Header 8X2: CONN HDR 16POS 0.1 TIN PCB; Manufacturer: Sullins; Manufacturer Part Number: PPTC082LFBN-RC; Connector Type: Header,Socket; Number of Positions: 16; Pitch: 0.1inch; Category: Connectors; Color: Black; Component Kind: Standard; ComponentLink1Description: http://www.sullinscorp.com/catalogs/101_Page80-81_HEADER_.100_2.54mm_FEMALE.pdf?src-supplier=Future+Electronics; ComponentLink1URL: http://www.sullinscorp.com/catalogs/101_Page80-81_HEADER_.100_2.54mm_FEMALE.pdf?src-supplier=Future+Electronics; ComponentLink2Description: https://drawings-pdf.s3.amazonaws.com/10492.pdf?src-supplier=Digi-Key; ComponentLink2URL: https://drawings-pdf.s3.amazonaws.com/10492.pdf?src-supplier=Digi-Key; Contact Material: Bronze; Contact Plating: Tin; Height: 8.5mm; Housing Material: Plastic; Lead Free: Lead Free; Length: 20.8mm; Library Name: Miscellaneous Connectors.IntLib; Mount: Through Hole; Number of Rows: 2; Package Quantity: 1000; Packaging: Bulk; RoHS: Yes; Row Spacing: 0.1inch; Signal Integrity: Connector; Stock 1: 3856; Supplier 1: Digi-Key; Supplier Part Number 1: S7076-ND; Termination: Solder; Width: 5mm
R14-R16 R20 R21 = Res Semi: Res Thick Film 0603 10K Ohm 1% 0.1W(1/10W) ±100ppm/C Molded SMD Automotive T/R; Manufacturer: Stackpole Electronics; Manufacturer Part Number: RMCF0603FT10K0; Max Power Dissipation: 100mW; Resistance: 10kR; Temperature Coefficient: 100ppm/°C; Case Code (Imperial): 0603; Case Code (Metric): 1608; Case/Package: 0603; Category: Chip SMD Resistors; Component Kind: Standard; ComponentLink1Description: http://www.rohm.com/web/global/datasheet/ESR01MZPF/esr-e; ComponentLink1URL: http://www.rohm.com/web/global/datasheet/ESR01MZPF/esr-e; ComponentLink2Description: http://components.arrow.com/part/dataview/43747082S6756917N1174?src-supplier=Arrow+Electronics; ComponentLink2URL: http://components.arrow.com/part/dataview/43747082S6756917N1174?src-supplier=Arrow+Electronics; ComponentLink3Description: https://www.seielect.com/catalog/sei-rmcf_rmcp.pdf?src-supplier=Digi-Key; ComponentLink3URL: https://www.seielect.com/catalog/sei-rmcf_rmcp.pdf?src-supplier=Digi-Key; ComponentLink4Description: http://www.seielect.com/catalog/sei-rmc.pdf?src-supplier=Future+Electronics; ComponentLink4URL: http://www.seielect.com/catalog/sei-rmc.pdf?src-supplier=Future+Electronics; ComponentLink5Description: https://www.seielect.com/catalog/SEI-RMCF_RMCP.pdf?src-supplier=Avnet; ComponentLink5URL: https://www.seielect.com/catalog/SEI-RMCF_RMCP.pdf?src-supplier=Avnet; ComponentLink6Description: https://www.verical.com/server-webapp/html/getDatasheet?partID=360913&src-supplier=Verical; ComponentLink6URL: https://www.verical.com/server-webapp/html/getDatasheet?partID=360913&src-supplier=Verical; Composition: Thick Film; Contact Plating: Tin,Matte; Depth: 0.8mm; Features: Automotive AEC-Q200; Height: 0.022inch; Lead Free: Lead Free; Length: 1.55mm; Max Operating Temperature: 155°C; Military Standard: Not; Min Operating Temperature: -55°C; Mount: Surface Mount; Number of Terminations: 2; Packaging: Tape and Reel; Power Rating: 100mW; Radiation Hardening: No; RoHS: Yes; Signal Integrity: Res Semi; Simulation: RES; Stock 1: 1608506; Supplier 1: Digi-Key; Supplier Part Number 1: RMCF0603FT10K0CT-ND; Tolerance: 1%; Value: 10k; Width: 0.031inch; Library Name: BrillianBit.SCHLIB
R17-R19 = Res Semi: RES 10M OHM 1% 1/10W 0603; Manufacturer: Stackpole Electronics; Manufacturer Part Number: RMCF0603FT10M0; Max Power Dissipation: 100mW; Resistance: 10MR; Temperature Coefficient: 200ppm/°C; Case Code (Imperial): 0603; Case Code (Metric): 1608; Case/Package: 0603; Category: Chip SMD Resistors; Component Kind: Standard; ComponentLink1Description: http://www.rohm.com/web/global/datasheet/ESR01MZPF/esr-e; ComponentLink1URL: http://www.rohm.com/web/global/datasheet/ESR01MZPF/esr-e; ComponentLink2Description: http://components.arrow.com/part/dataview/47093497S7925112N1174?src-supplier=Arrow+Electronics; ComponentLink2URL: http://components.arrow.com/part/dataview/47093497S7925112N1174?src-supplier=Arrow+Electronics; ComponentLink3Description: https://www.seielect.com/catalog/SEI-Packaging.pdf?src-supplier=Digi-Key; ComponentLink3URL: https://www.seielect.com/catalog/SEI-Packaging.pdf?src-supplier=Digi-Key; ComponentLink4Description: https://www.seielect.com/catalog/SEI-RMCF_RMCP.pdf?src-supplier=Avnet; ComponentLink4URL: https://www.seielect.com/catalog/SEI-RMCF_RMCP.pdf?src-supplier=Avnet; ComponentLink5Description: https://www.verical.com/server-webapp/html/getDatasheet?partID=691637&src-supplier=Verical; ComponentLink5URL: https://www.verical.com/server-webapp/html/getDatasheet?partID=691637&src-supplier=Verical; Composition: Thick Film; Contact Plating: Tin,Matte; Depth: 0.8mm; Features: Automotive AEC-Q200; Height: 0.022inch; Lead Free: Lead Free; Length: 0.061inch; Max Operating Temperature: 155°C; Military Standard: Not; Min Operating Temperature: -55°C; Mount: Surface Mount; Number of Terminations: 2; Packaging: Tape and Reel; Radiation Hardening: No; RoHS: Yes; Signal Integrity: Res Semi; Simulation: RES; Stock 1: 61133; Supplier 1: Digi-Key; Supplier Part Number 1: RMCF0603FT10M0CT-ND; Tolerance: 1%; Value: 10M; Width: 0.031inch; Library Name: BrillianBit.SCHLIB
R22 R23 R28 R43-R48 = Res Semi: RES 220 OHM 1% 1/10W 0603; Manufacturer: Stackpole Electronics; Manufacturer Part Number: RMCF0603FT220R; Max Power Dissipation: 100mW; Resistance: 220R; Temperature Coefficient: 100ppm/°C; Case Code (Imperial): 0603; Case Code (Metric): 1608; Case/Package: 0603; Category: Chip SMD Resistors; Component Kind: Standard; ComponentLink1Description: http://www.rohm.com/web/global/datasheet/ESR01MZPF/esr-e; ComponentLink1URL: http://www.rohm.com/web/global/datasheet/ESR01MZPF/esr-e; ComponentLink2Description: https://www.seielect.com/catalog/SEI-Packaging.pdf?src-supplier=Avnet; ComponentLink2URL: https://www.seielect.com/catalog/SEI-Packaging.pdf?src-supplier=Avnet; ComponentLink3Description: https://www.seielect.com/catalog/sei-rmcf_rmcp.pdf?src-supplier=Digi-Key; ComponentLink3URL: https://www.seielect.com/catalog/sei-rmcf_rmcp.pdf?src-supplier=Digi-Key; ComponentLink4Description: https://www.seielect.com/catalog/SEI-RMCF_RMCP.pdf?src-supplier=Avnet; ComponentLink4URL: https://www.seielect.com/catalog/SEI-RMCF_RMCP.pdf?src-supplier=Avnet; ComponentLink5Description: http://www.seielect.com/Catalog/SEI-rmc.pdf?src-supplier=Future+Electronics; ComponentLink5URL: http://www.seielect.com/Catalog/SEI-rmc.pdf?src-supplier=Future+Electronics; ComponentLink6Description: https://www.seielect.com/catalog/SEI-RMCF_RMCP.pdf?src-supplier=Avnet+Asia; ComponentLink6URL: https://www.seielect.com/catalog/SEI-RMCF_RMCP.pdf?src-supplier=Avnet+Asia; ComponentLink7Description: https://www.seielect.com/catalog/SEI-Packaging.pdf?src-supplier=Verical; ComponentLink7URL: https://www.seielect.com/catalog/SEI-Packaging.pdf?src-supplier=Verical; ComponentLink8Description: https://www.seielect.com/catalog/SEI-Packaging.pdf?src-supplier=Arrow+Electronics; ComponentLink8URL: https://www.seielect.com/catalog/SEI-Packaging.pdf?src-supplier=Arrow+Electronics; ComponentLink9Description: https://www.seielect.com/catalog/SEI-Packaging.pdf?src-supplier=Quest; ComponentLink9URL: https://www.seielect.com/catalog/SEI-Packaging.pdf?src-supplier=Quest; ComponentLink10Description: http://components.arrow.com/part/dataview/50498833S229663N1174?src-supplier=Arrow+Electronics; ComponentLink10URL: http://components.arrow.com/part/dataview/50498833S229663N1174?src-supplier=Arrow+Electronics; ComponentLink11Description: https://www.seielect.com/catalog/SEI-Packaging.pdf?src-supplier=Digi-Key; ComponentLink11URL: https://www.seielect.com/catalog/SEI-Packaging.pdf?src-supplier=Digi-Key; Contact Plating: Tin,Matte; Features: Automotive AEC-Q200; Height: 0.022inch; Lead Free: Lead Free; Length: 0.061inch; Max Operating Temperature: 155°C; Min Operating Temperature: -55°C; Mount: Surface Mount; Number of Terminations: 2; Packaging: Tape and Reel; Power Rating: 100mW; Radiation Hardening: No; Resistor Type: Thick Film; RoHS: Yes; Signal Integrity: Res Semi; Simulation: RES; Stock 1: 110365; Supplier 1: Digi-Key; Supplier Part Number 1: RMCF0603FT220RCT-ND; Tolerance: 1%; Value: 220; Width: 0.031inch; Library Name: BrillianBit.SCHLIB
R42 = Res Semi: RES 1K OHM 1% 1/16W 0402; Manufacturer: Stackpole Electronics; Manufacturer Part Number: RMCF0402FT1K00; Max Power Dissipation: 63mW; Resistance: 1kR; Temperature Coefficient: 100ppm/°C; Case Code (Imperial): 0402; Case Code (Metric): 1005; Case/Package: 0402; Category: Chip SMD Resistors; Component Kind: Standard; ComponentLink1Description: http://www.rohm.com/web/global/datasheet/ESR01MZPF/esr-e; ComponentLink1URL: http://www.rohm.com/web/global/datasheet/ESR01MZPF/esr-e; ComponentLink2Description: https://datasheet.ciiva.com/15193/getdatasheetpartid-365385-15193418.pdf?src-supplier=Verical; ComponentLink2URL: https://datasheet.ciiva.com/15193/getdatasheetpartid-365385-15193418.pdf?src-supplier=Verical; ComponentLink3Description: https://datasheet.ciiva.com/24336/sei-rmcp-24336266.pdf?src-supplier=Onlinecomponents.com; ComponentLink3URL: https://datasheet.ciiva.com/24336/sei-rmcp-24336266.pdf?src-supplier=Onlinecomponents.com; Composition: Thick Film; Contact Plating: Tin,Matte; Depth: 0.5mm; Features: Automotive AEC-Q200; Height: 0.016inch; Lead Free: Lead Free; Length: 1mm; Max Operating Temperature: 155°C; Military Standard: Not; Min Operating Temperature: -55°C; Mount: Surface Mount; Number of Terminations: 2; Packaging: Tape and Reel; Power Rating: 63mW; Radiation Hardening: No; RoHS: Yes; Signal Integrity: Res Semi; Simulation: RES; Stock 1: 910605; Supplier 1: Digi-Key; Supplier Part Number 1: RMCF0402FT1K00CT-ND; Tolerance: 1%; Value: 1k; Width: 0.02inch; Library Name: BrillianBit.SCHLIB
SW1 = SW_SPST_6MM: PTS636 Series SPST-NO Off-Mom 250 gf Top Actuated SMT Tactile Switch; Manufacturer: ITT C&K; Manufacturer Part Number: PTS636SK25FSMTRLFS; Component Kind: Standard; RoHS: No; Supplier 1: Digi-Key; Supplier Part Number 1: CKN12330-1-ND; Library Name: Arbury_SCH.SchLib
U6 = CMP-1525-00014-1: Bluetooth Smart and 2.4 GHz Proprietary SoC, 256 kB Flash, 16 kB RAM, -25 to 75 degC, 48-Pin QFN (QF), RoHS, Tape and Reel; Manufacturer: Nordic Semiconductor; Core Architecture: ARM; Current - Receiving: 13.4mA; Current - Transmitting: 16mA; Data Bus Width: 32b; Data Rate: 2000Kbps; Frequency: 2.4GHz; Interface: SPI,UART,2-Wire,I2C; Memory Size: 16KB; Number of GPIO: 32; Number of Timers/Counters: 2; Operating Supply Voltage: 3.6V; Sensitivity: -96dBm; Case/Package: QFN; Category: RF Receivers, Transceivers; Component Kind: Standard; ComponentLink1Description: Manufacturer URL; ComponentLink1URL: http://www.nordicsemi.com/eng/Products/; ComponentLink2Description: Datasheet; ComponentLink2URL: http://www.nordicsemi.com/eng/content/download/13358/214991/file/nRF51822_PS%20v3.1.pdf; ComponentLink3Description: Package Specification; ComponentLink3URL: http://www.nordicsemi.com/eng/content/download/13358/214991/file/nRF51822_PS%20v3.1.pdf; ComponentLink4Description: https://datasheet.ciiva.com/29856/prod+brief+nrf51822+v2-1-29856539.pdf?src-supplier=Digi-Key; ComponentLink4URL: https://datasheet.ciiva.com/29856/prod+brief+nrf51822+v2-1-29856539.pdf?src-supplier=Digi-Key; ComponentLink5Description: https://datasheet.ciiva.com/29856/prod+brief+nrf51822+v2-1-29856540.pdf?src-supplier=Digi-Key; ComponentLink5URL: https://datasheet.ciiva.com/29856/prod+brief+nrf51822+v2-1-29856540.pdf?src-supplier=Digi-Key; ComponentLink6Description: https://datasheet.ciiva.com/29856/prod+brief+nrf51822+v2-1-29856541.pdf?src-supplier=Digi-Key; ComponentLink6URL: https://datasheet.ciiva.com/29856/prod+brief+nrf51822+v2-1-29856541.pdf?src-supplier=Digi-Key; Library Name: Altium Content Vault; Manufacturer Part Number: nRF51822-QFAA-R7; Max Operating Temperature: 75°C; Memory Type: FLASH; Min Operating Temperature: -25°C; Number of Pins: 48; Package Quantity: 1000; Packaging: Tape and Reel; RAM Size: 16KB; RoHS: Yes; Stock 1: 30423; Supplier 1: Digi-Key; Supplier Part Number 1: 1490-1031-1-ND; Weight: 0.005309oz
U8 = IC_MMA8653FCR1: NXP - MMA8653FCR1 - ACCELEROMETER, 3-AXIS, DIGITAL, DFN-10; Manufacturer: NXP Freescale; Axis: X, Y, Z; Bandwidth: 400Hz; Interface: I2C,Serial; Max Supply Voltage: 3.6V; Min Supply Voltage: 1.95V; Nominal Supply Current: 100mA; Output Type: I2C,Digital; Resolution: 10b; Sensitivity: 256LSB/g; Case/Package: DFN; Category: Accelerometers; Component Kind: Standard; ComponentLink1Description: https://datasheet.ciiva.com/28626/1837497-28626338.pdf?src-supplier=Element14; ComponentLink1URL: https://datasheet.ciiva.com/28626/1837497-28626338.pdf?src-supplier=Element14; ComponentLink2Description: https://datasheet.ciiva.com/12092/mma8653fc-64125-12092393.pdf?src-supplier=Mouser; ComponentLink2URL: https://datasheet.ciiva.com/12092/mma8653fc-64125-12092393.pdf?src-supplier=Mouser; ComponentLink3Description: https://datasheet.ciiva.com/15222/getdatasheetpartid-671580-15222334.pdf?src-supplier=Verical; ComponentLink3URL: https://datasheet.ciiva.com/15222/getdatasheetpartid-671580-15222334.pdf?src-supplier=Verical; ComponentLink4Description: https://datasheet.ciiva.com/16462/mma8653fc-16462973.pdf?src-supplier=Future+Electronics; ComponentLink4URL: https://datasheet.ciiva.com/16462/mma8653fc-16462973.pdf?src-supplier=Future+Electronics; Depth: 2mm; Halogen Free: Halogen Free; Height: 0.95mm; Lead Free: Lead Free; Length: 2mm; Manufacturer Part Number: MMA8653FCR1; Max Operating Temperature: 85°C; Min Operating Temperature: -40°C; Number of Pins: 10; Package Quantity: 1000; Packaging: Tape and Reel; Radiation Hardening: No; REACH SVHC: No SVHC; RoHS: Yes; Stock 1: 32251; Supplier 1: Digi-Key; Supplier Part Number 1: MMA8653FCR1CT-ND; Weight: 0.000508oz; Width: 2mm; Library Name: Arbury_IC.SchLib
X1 = XTAL_16MHZ_3.2X2.5MM: Manufacturer: ECS International; Manufacturer Part Number: ECS-160-10-36B-CKM-TR; Component Kind: Standard; Description: XTAL 16MHZ 2.5 x 2 mm; RoHS: No; Stock 1: 1997; Supplier 1: Digi-Key; Supplier Part Number 1: 50-ECS-160-10-36B-CKM-CT-ND; Library Name: Arbury_SCH.SchLib
